# Altium schematic sheet STM32F_Board_Schematic.SchDoc
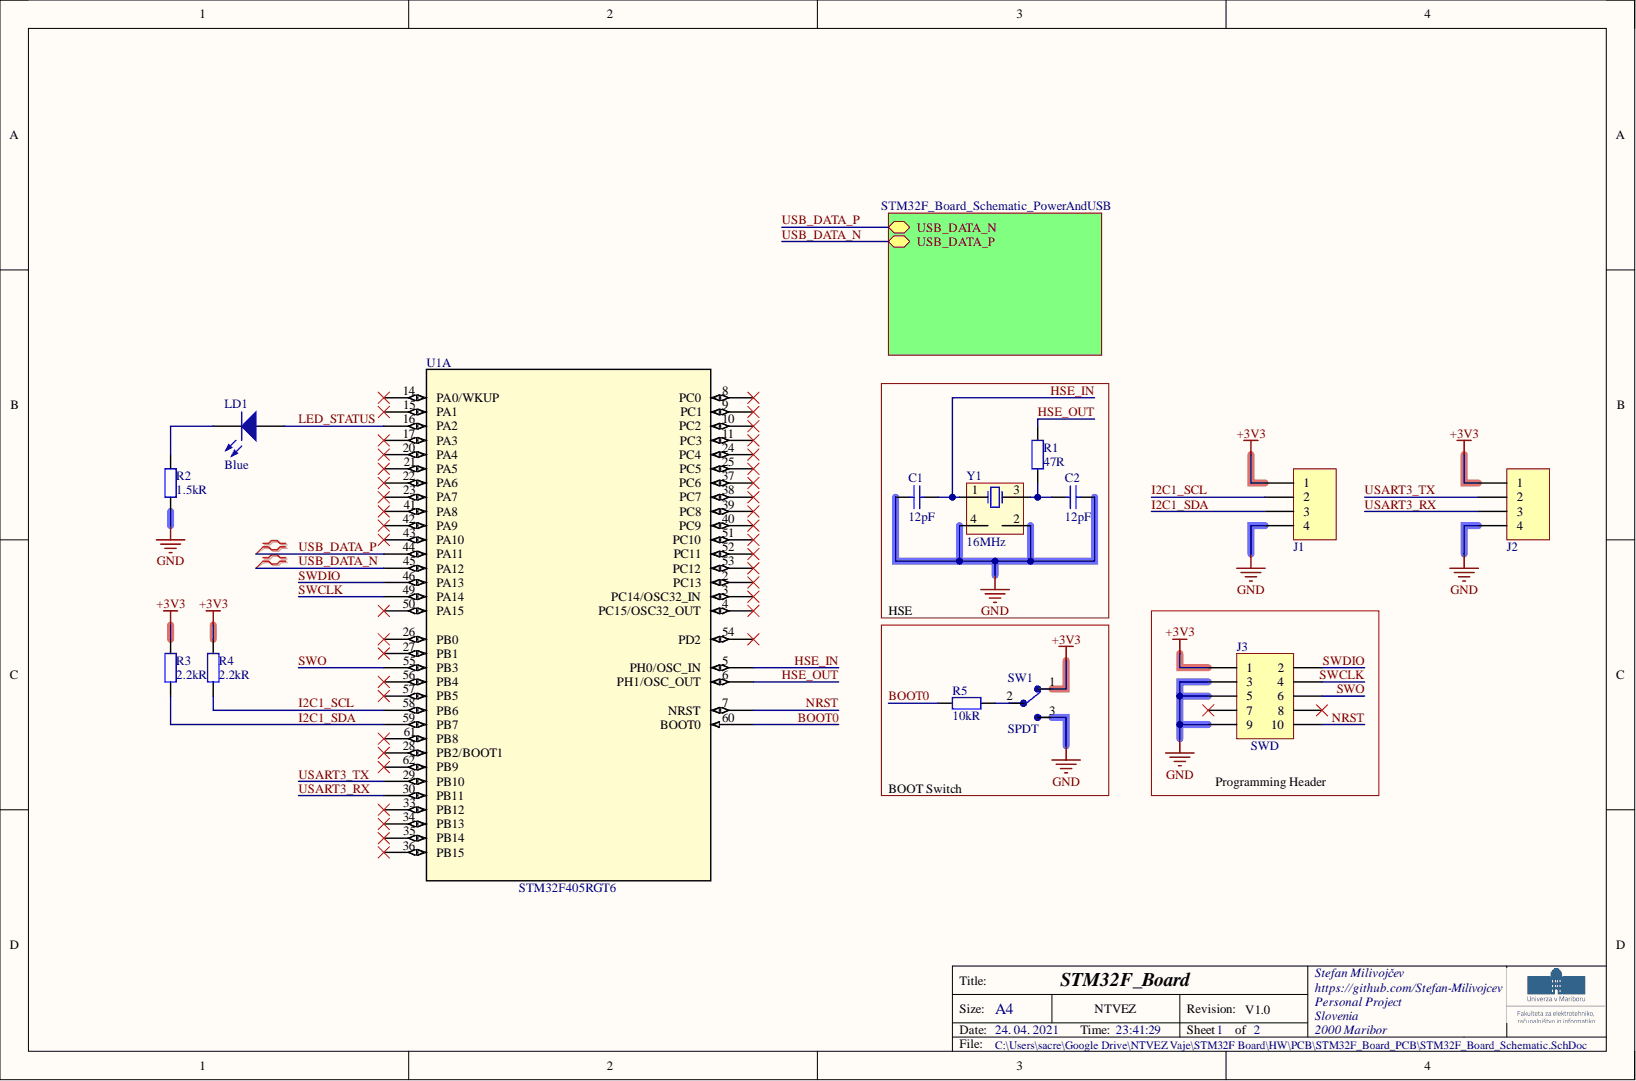
[diagram p0: STM32F_Board_Schematic.SchDoc]

## Parts
C1  12pF  [Capacitor_0603IMP]
C2  12pF  [Capacitor_0603IMP]
J1  Header_Male_1x4  [HDR1X4]  (value not drawn)
J2  Header_Male_1x4  [HDR1X4]  (value not drawn)
J3  SWD  [SAMTEC-FTS-105-01-X-DV]
LD1  Blue  [LED_0603IMP_BLUE]
R1  47R  [Resistor_0805IMP]
R2  1.5kR  [Resistor_0805IMP]
R3  2.2kR  [Resistor_0805IMP]
R4  2.2kR  [Resistor_0805IMP]
R5  10kR  [Resistor_0805IMP]
SW1  SPDT  [SW_JS102011JCQN]
U1  STM32F405RGT6  [LQFP64-10x10mm]  (part A)
Y1  16MHz  [Oscillator_3225]

## Nets
+3V3: J1.1 J2.1 J3.1 R3.2 R4.2 SW1.1
GND: C1.1 C2.2 J1.4 J2.4 J3.3 J3.5 J3.9 R2.1 SW1.3 Y1.2 Y1.4
BOOT0: R5.1 U1.60(BOOT0)
HSE_IN: C1.2 U1.5(PH0/OSC_IN) Y1.1
HSE_OUT: R1.2 U1.6(PH1/OSC_OUT)
I2C1_SCL: J1.2 R4.1 U1.58(PB6)
I2C1_SDA: J1.3 R3.1 U1.59(PB7)
LED_STATUS: LD1.1 U1.16(PA2)
NRST: J3.10 U1.7(NRST)
SWCLK: J3.4 U1.49(PA14)
SWDIO: J3.2 U1.46(PA13)
SWO: J3.6 U1.55(PB3)
USART3_RX: J2.3 U1.30(PB11)
USART3_TX: J2.2 U1.29(PB10)
USB_DATA_N: U1.45(PA12)
USB_DATA_P: U1.44(PA11)
NetC2_1: C2.1 R1.1 Y1.3
NetJ3_7: J3.7
NetJ3_8: J3.8
NetLD1_2: LD1.2 R2.2
NetR5_2: R5.2 SW1.2
NetU1_2: U1.2(PC13)
NetU1_3: U1.3(PC14/OSC32_IN)
NetU1_4: U1.4(PC15/OSC32_OUT)
NetU1_8: U1.8(PC0)
NetU1_9: U1.9(PC1)
NetU1_10: U1.10(PC2)
NetU1_11: U1.11(PC3)
NetU1_14: U1.14(PA0/WKUP)
NetU1_15: U1.15(PA1)
NetU1_17: U1.17(PA3)
NetU1_20: U1.20(PA4)
NetU1_21: U1.21(PA5)
NetU1_22: U1.22(PA6)
NetU1_23: U1.23(PA7)
NetU1_24: U1.24(PC4)
NetU1_25: U1.25(PC5)
NetU1_26: U1.26(PB0)
NetU1_27: U1.27(PB1)
NetU1_28: U1.28(PB2/BOOT1)
NetU1_33: U1.33(PB12)
NetU1_34: U1.34(PB13)
NetU1_35: U1.35(PB14)
NetU1_36: U1.36(PB15)
NetU1_37: U1.37(PC6)
NetU1_38: U1.38(PC7)
NetU1_39: U1.39(PC8)
NetU1_40: U1.40(PC9)
NetU1_41: U1.41(PA8)
NetU1_42: U1.42(PA9)
NetU1_43: U1.43(PA10)
NetU1_50: U1.50(PA15)
NetU1_51: U1.51(PC10)
NetU1_52: U1.52(PC11)
NetU1_53: U1.53(PC12)
NetU1_54: U1.54(PD2)
NetU1_56: U1.56(PB4)
NetU1_57: U1.57(PB5)
NetU1_61: U1.61(PB8)
NetU1_62: U1.62(PB9)

## Library data of the parts
C1 C2 = C_12pF_0603IMP: Capacitor; Ceramic; Cap; 12.0pF; Tol 5%; SMT; Vol-Rtg 50V; C0G; Manufacturer: KEMET; Manufacturer Part Number: C0603C120J5GACTU; Temperature Coefficient: 30ppm/°C; Capacitance: 12pF; Case/Package: 0603; Category: Ceramic Capacitors; Component Kind: Standard; ComponentLink1Description: https://datasheet.ciiva.com/28608/1372242-28608539.pdf?src-supplier=Element14; ComponentLink1URL: https://datasheet.ciiva.com/28608/1372242-28608539.pdf?src-supplier=Element14; Depth: 0.8mm; Dielectric: C0G; Dielectric Material: Ceramic; Height: 0.8mm; Lead Free: Lead Free; Length: 1.6mm; Library Name: STM32F_Board.IntLib; Max Operating Temperature: 125°C; Min Operating Temperature: -55°C; Mount: Surface Mount; Packaging: Tape and Reel; Pricing 1: 10=0,03410, 100=0,02710, 500=0,01900, 1000=0,01440, 2000=0,01410 (GBP); Radiation Hardening: No; RoHS: Yes; Stock 1: 37802; Supplier 1: Farnell; Supplier Part Number 1: 1414612; Termination: SMD/SMT; Tolerance: 5%; Voltage: 50V; Voltage Rating (DC): 50V
J1 J2 = Header_Male_1x4: MULTICOMP - 2211S-04G - Board-To-Board Connector, 2.54 mm, 4 Contacts, Header, 2211S Series, Through Hole, 1 Rows; Gender: Male; Insulation Resistance: 1GR; Number of Contacts: 4; Category: Connectors; Component Kind: Standard; ComponentLink1Description: https://datasheet.ciiva.com/1433/1518407-1433871.pdf?src-supplier=Element14; ComponentLink1URL: https://datasheet.ciiva.com/1433/1518407-1433871.pdf?src-supplier=Element14; Contact Material: Copper Alloy; Contact Plating: Gold; Flammability Rating: UL94 V-0; Height: 0.47inch; Length: 0.39inch; Library Name: STM32F_Board.IntLib; Manufacturer: Multicomp; Manufacturer Part Number: 2211S-04G; Mount: Through Hole; Number of Rows: 1; Pricing 1: 50=0,02110, 500=0,01560, 1500=0,01340, 2500=0,01090 (GBP); REACH SVHC: No SVHC; RoHS: No; RoHS Compliant: No; Stock 1: 200994; Supplier 1: Farnell; Supplier Part Number 1: 1593413; Termination: Through Hole; Weight: 0.1lb; Width: 0.25inch
J3 = Header_1.27mm_male_SMD: SAMTEC - FTS-105-01-L-DV - CONNECTOR, HEADER, SMT, 1.27MM, 10WAY; Connector Type: Male Pin; Current Rating: 1.75A; Gender: Male; Number of Contacts: 10; Row Spacing: 0.05inch; Category: Headers and Wire Housings; Color: Black; Component Kind: Standard; ComponentLink1Description: https://datasheet.ciiva.com/25617/0900766b81142f3c-25617158.pdf?src-supplier=RSComponents; ComponentLink1URL: https://datasheet.ciiva.com/25617/0900766b81142f3c-25617158.pdf?src-supplier=RSComponents; ComponentLink2Description: https://datasheet.ciiva.com/22677/1731559-22677531.pdf?src-supplier=Element14; ComponentLink2URL: https://datasheet.ciiva.com/22677/1731559-22677531.pdf?src-supplier=Element14; ComponentLink3Description: https://datasheet.ciiva.com/6881/fts-1xx-xx-xx-xx-xx-mkt-6881558.pdf?src-supplier=Digi-Key; ComponentLink3URL: https://datasheet.ciiva.com/6881/fts-1xx-xx-xx-xx-xx-mkt-6881558.pdf?src-supplier=Digi-Key; ComponentLink4Description: https://datasheet.ciiva.com/26936/getdatasheetpartid-221786-26936875.pdf?src-supplier=Verical; ComponentLink4URL: https://datasheet.ciiva.com/26936/getdatasheetpartid-221786-26936875.pdf?src-supplier=Verical; Contact Material: Bronze; Contact Mating Length: 0.12inch; Contact Plating: Gold; Contact Type: Male Pin; Depth: 3.42mm; Housing Color: Black; Housing Material: Polymer; Lead Free: Lead Free; Length: 6.35mm; Library Name: STM32F_Board.IntLib; Manufacturer: Samtec; Manufacturer Part Number: FTS-105-01-L-DV; Max Current Rating: 1.75A; Max Operating Temperature: 125°C; Min Operating Temperature: -55°C; Mount: Surface Mount; Number of Positions: 10; Number of Rows: 2; Orientation: Straight; Package Quantity: 1; Packaging: Bulk; Pitch: 1.27mm; Pricing 1: 1=1,36000, 10=1,18000, 100=1,07000, 250=0,99200, 500=0,83200, 1000=0,71500 (GBP); Radiation Hardening: No; REACH SVHC: No SVHC; RoHS: Yes; RoHS Compliant: Yes; Series: FTS; Stock 1: 2610; Supplier 1: Farnell; Supplier Part Number 1: 1667729; Termination: Solder
LD1 = LED_BLUE_0603IMP: LED, SMD, 0603, BLUE; Manufacturer: Kingbright; Manufacturer Part Number: KPT-1608QBC-D; Dominant Wavelength: 470nm; Forward Current: 20mA; Forward Voltage: 3.3V; Lens Color: Clear; Lens Style: Rectangular,Diffused,Colorless,Circular; Luminous Intensity: 100mcd; Number of LEDs: 1; Viewing Angle: 120°; Wavelength: 465nm; Case/Package: 1608; Category: LEDs; Color: Blue; Component Kind: Standard; ComponentLink1Description: https://datasheet.ciiva.com/8060/1655865-8060610.pdf?src-supplier=Element14; ComponentLink1URL: https://datasheet.ciiva.com/8060/1655865-8060610.pdf?src-supplier=Element14; Library Name: STM32F_Board.IntLib; Mount: Surface Mount; Number of Pins: 2; Packaging: Tape and Reel; Pricing 1: 5=0,24500, 50=0,18500, 100=0,16200, 500=0,13800, 1000=0,13500 (GBP); Radiation Hardening: No; REACH SVHC: No SVHC; RoHS: Yes; RoHS Compliant: Yes; Series: KPT; Stock 1: 483; Supplier 1: Farnell; Supplier Part Number 1: 2217978
R1 = Resistor_47R_0805IMP: RES, THICK FILM, 47OHM, 1%, 0.125W; Manufacturer: Multicomp; Manufacturer Part Number: MCWR08X47R0FTL; Power Rating: 125mW; Temperature Coefficient: 100ppm/°C; Voltage Rating: 150V; Case Code (Imperial): 0805; Case Code (Metric): 2012; Case/Package: 0805; Component Kind: Standard; ComponentLink1Description: https://datasheet.ciiva.com/46398/1849611-46398382.pdf?src-supplier=Element14; ComponentLink1URL: https://datasheet.ciiva.com/46398/1849611-46398382.pdf?src-supplier=Element14; ComponentLink2Description: https://datasheet.ciiva.com/46398/1849611-46398383.pdf?src-supplier=Element14; ComponentLink2URL: https://datasheet.ciiva.com/46398/1849611-46398383.pdf?src-supplier=Element14; Library Name: STM32F_Board.IntLib; Packaging: Cut Tape; Pricing 1: 10=0,00850, 100=0,00730, 500=0,00610, 1000=0,00450, 2500=0,00380 (GBP); REACH SVHC: No SVHC; Resistance: 47R; Resistor Type: Thick Film; RoHS: Yes; Stock 1: 73470; Supplier 1: Farnell; Supplier Part Number 1: 2447666; Tolerance: 1%; Voltage Rating (DC): 150V
R2 = Resistor_1K5_0805IMP: RES, 1K5, 1%, 0.125W, 0805; Manufacturer: Multicomp; Manufacturer Part Number: MCWR08X1501FTL; Power Rating: 125mW; Temperature Coefficient: 100ppm/°C; Voltage Rating: 150V; Case Code (Imperial): 0805; Case Code (Metric): 2012; Case/Package: 0805; Category: Uncategorized; Component Kind: Standard; ComponentLink1Description: http://www.farnell.com/datasheets/2563624.pdf?src-supplier=Farnell; ComponentLink1URL: http://www.farnell.com/datasheets/2563624.pdf?src-supplier=Farnell; ComponentLink2Description: http://www.farnell.com/datasheets/1849611.pdf?src-supplier=Element14; ComponentLink2URL: http://www.farnell.com/datasheets/1849611.pdf?src-supplier=Element14; Library Name: STM32F_Board.IntLib; Mount: Surface Mount; Packaging: Tape and Reel; Pricing 1: 10=0,00840, 100=0,00720, 500=0,00600, 1000=0,00440, 2500=0,00360 (GBP); REACH SVHC: Unknown; Resistance: 1.5kR; Resistor Type: Thick Film; RoHS: Yes; Stock 1: 45138; Supplier 1: Farnell; Supplier Part Number 1: 2447592; Tolerance: 1%; Voltage Rating (DC): 150V
R3 R4 = Resistor_2K2_0805IMP: Resistor 2.2 kohm, 125 mW, - 1%, 150 V; Manufacturer: Multicomp; Manufacturer Part Number: MCWR08X2201FTL; Power Rating: 125mW; Temperature Coefficient: 100ppm/°C; Voltage Rating: 150V; Case Code (Imperial): 0805; Case Code (Metric): 2012; Case/Package: 0805; Category: Uncategorized; Component Kind: Standard; ComponentLink1Description: http://www.farnell.com/datasheets/2563624.pdf?src-supplier=Farnell; ComponentLink1URL: http://www.farnell.com/datasheets/2563624.pdf?src-supplier=Farnell; ComponentLink2Description: http://www.farnell.com/datasheets/1849611.pdf?src-supplier=Element14; ComponentLink2URL: http://www.farnell.com/datasheets/1849611.pdf?src-supplier=Element14; Library Name: STM32F_Board.IntLib; Mount: Surface Mount; Packaging: Tape and Reel; Pricing 1: 10=0,00900, 100=0,00780, 500=0,00650, 1000=0,00480, 2500=0,00400 (GBP); REACH SVHC: Unknown; Resistance: 2.2kR; Resistor Type: Thick Film; RoHS: Yes; Stock 1: 54745; Supplier 1: Farnell; Supplier Part Number 1: 2447623; Tolerance: 1%; Voltage Rating (DC): 150V
R5 = Resistor_10K_0805IMP: SMD Chip Resistor, Thick Film, 10 kohm, 150 V, 0805 [2012 Metric], 125 mW,  1%,; Manufacturer: Multicomp; Manufacturer Part Number: MCWR08X1002FTL; Power Rating: 125mW; Temperature Coefficient: 100ppm/°C; Voltage Rating: 150V; Case Code (Imperial): 0805; Case Code (Metric): 2012; Case/Package: 0805; Category: Uncategorized; Component Kind: Standard; ComponentLink1Description: https://datasheet.ciiva.com/46381/1849611-46381605.pdf?src-supplier=Element14; ComponentLink1URL: https://datasheet.ciiva.com/46381/1849611-46381605.pdf?src-supplier=Element14; Library Name: STM32F_Board.IntLib; Mount: Surface Mount; Packaging: Cut Tape; Pricing 1: 10=0,00840, 100=0,00720, 500=0,00600, 1000=0,00440, 2500=0,00360 (GBP); REACH SVHC: Unknown; Resistance: 10kR; Resistor Type: Thick Film; RoHS: Yes; Stock 1: 135476; Supplier 1: Farnell; Supplier Part Number 1: 2447553; Tolerance: 1%; Voltage Rating (DC): 150V
SW1 = JS102011JCQN: C & K COMPONENTS - Schiebeschalter, Subminiatur, SPDT, Ein-Ein, Oberflächenmontage, JS Series, 300 mA; Manufacturer: ITT C&K; Actuator Length: 2mm; Actuator Material: Nylon,Polyamide; Actuator Type: Standard; Current Rating: 300mA; Electrical Life: 5000Cycles; Switch Function: On-On; Voltage Rating (DC): 6V; Component Kind: Standard; ComponentLink1Description: http://www.farnell.com/datasheets/2674788.pdf?src-supplier=Farnell; ComponentLink1URL: http://www.farnell.com/datasheets/2674788.pdf?src-supplier=Farnell; ComponentLink2Description: https://www.ckswitches.com/media/1422/js.pdf?src-supplier=Digi-Key; ComponentLink2URL: https://www.ckswitches.com/media/1422/js.pdf?src-supplier=Digi-Key; ComponentLink3Description: http://www.farnell.com/datasheets/2674788.pdf?src-supplier=Newark; ComponentLink3URL: http://www.farnell.com/datasheets/2674788.pdf?src-supplier=Newark; ComponentLink4Description: http://www.farnell.com/datasheets/2674788.pdf?src-supplier=Element14; ComponentLink4URL: http://www.farnell.com/datasheets/2674788.pdf?src-supplier=Element14; Contact Material: Copper Alloy; Contact Plating: Silver; Library Name: STM32F_Board.IntLib; Manufacturer Part Number: JS102011JCQN; Max Operating Temperature: 85°C; Min Operating Temperature: -40°C; Mount: Surface Mount; Packaging: Cut Tape; Pricing 1: 1=0,61800, 10=0,59900, 25=0,58700, 50=0,52300, 100=0,49800, 250=0,48600, 500=0,40900 (GBP); RoHS: Yes; Stock 1: 892; Supplier 1: Farnell; Supplier Part Number 1: 3275788; Switch Travel: 2mm; Throw Configuration: SPDT
U1 = STM32F405RGT6: STM32F4 MCU; Built_By: EMA_UL_Team; SourceLibrary: Updates_to_database_2020-10-19; Vendor: STMicroelectronics; Component Kind: Standard; Library Name: STM32F_Board.IntLib; Manufacturer_Part_Number: STM32F405RGT6; RefDes: RefDes; Supplier 1: Farnell; Supplier Part Number 1: 2064363; Type: DEV; Copyright: Copyright (C) 2018 Accelerated Designs. All rights reserved
Y1 = Crystal_16MHz_3225: Oscillator, 16Mhz, 3.2Mm X 2.5Mm, Cmos Frequency Nom 16Mhz; Manufacturer: ABRACON; Manufacturer Part Number: ABM8AIG-16.000MHZ-12-2Z-T; Component Kind: Standard; Library Name: STM32F_Board.IntLib; Pricing 1: 5=0,58300, 50=0,47400, 250=0,41200, 500=0,40100, 1500=0,39600, 3000=0,39000, 15000=0,38200, 30000=0,37400 (GBP); Stock 1: 655; Supplier 1: Farnell; Supplier Part Number 1: 2849442
